# Altium schematic sheet rtc.SchDoc
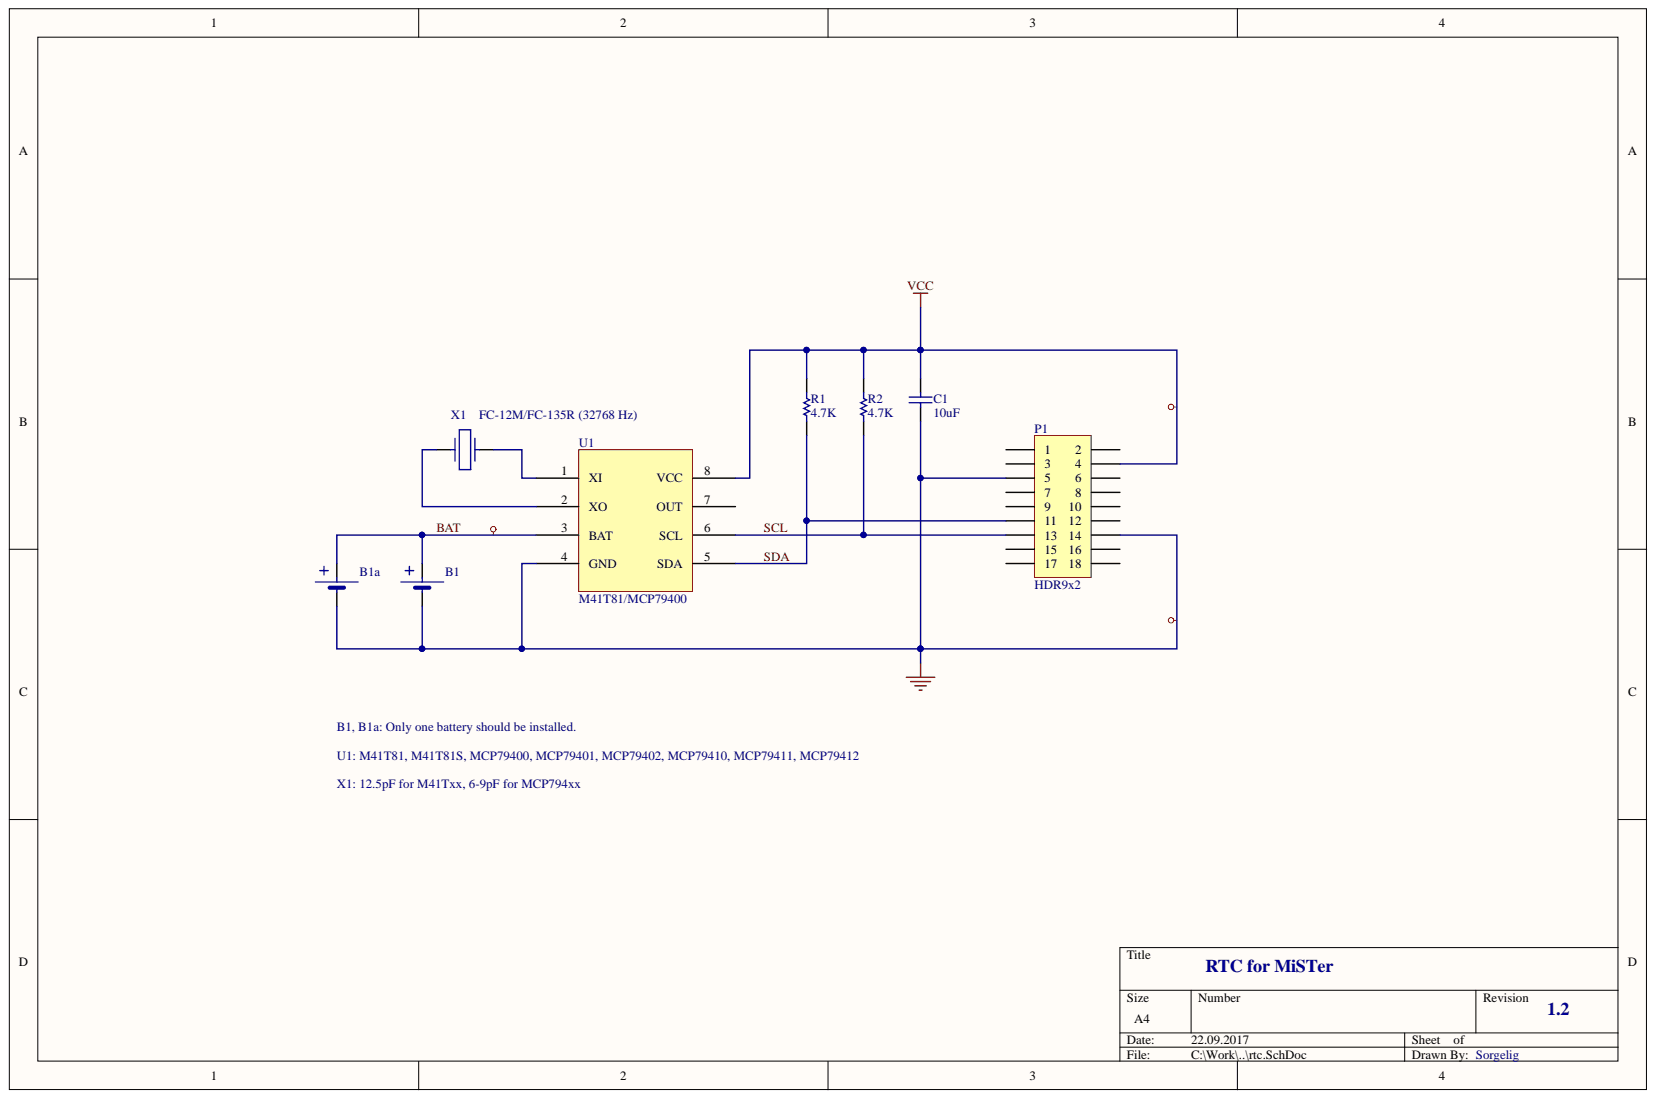
[diagram p0: rtc.SchDoc]

## Parts
B1  (no value)  [KS3000]
B1a  BAT  [BAT]  (value not drawn)
C1  value 10uF  [C0805]  (hidden comment: C0805)
P1  HDR9x2  [hdr9x2]
R1  4.7K  [R0805]
R2  4.7K  [R0805]
U1  M41T81/MCP79400  [SOIC8P]
X1  FC-12M/FC-135R (32768 Hz)  [OSC]

## Nets
GND: B1.1 B1a.1 C1.1 P1.5 P1.14 U1.4(GND)
VCC: C1.2 P1.4 R1.2 R2.2 U1.8(VCC)
BAT: B1.2 B1a.2 U1.3(BAT)
SCL: P1.13 R2.1 U1.6(SCL)
SDA: P1.11 R1.1 U1.5(SDA)
NetU1_1: U1.1(XI) X1.1
NetU1_2: U1.2(XO) X1.2

Not connected: P1 1 2 3 6 7 8 9 10 12 15 16 17 18; U1 7(OUT)

## Library data of the parts
B1 = BAT_KS3000: Component Kind: Standard; Library Name: lib.SchLib
C1 = C0805: Ceramic Chip Capacitor - Standard; Note: Footprint corresponds to dimensions for bulk cassette packaging. This case size has tightened tolerances.; Code_IPC: 2012[0805]; Code_JEITA: 0805; Component Kind: Standard; ComponentLink1Description: Manufacturer Link; ComponentLink1URL: http://www.kemet.com/; ComponentLink2Description: Datasheet; ComponentLink2URL: http://www.kemet.com/kemet/web/homepage/kechome.nsf/vapubfilesname/F3102TA.pdf/$file/F3102TA.pdf; DatasheetDocument: Mar-2001; LatestRevisionDate: 19-Mar-2004; LatestRevisionNote: Silkscreen size reduced.; Library Name: KEMET Chip Capacitor.IntLib; PackageDocument: Mar-2001; PackageReference: 0805; Published: 17-Jul-2002; Signal Integrity: C0805; Simulation: CAP; Publisher: Altium Limited
R1 R2 = ERJ6RS: Low Ohmic Value Thick Film Chip Resistor, 0.1 Ohm to 0.2 Ohm Range, 1%, 2% and 5% Tolerance, 0805 Size, 0.125 W; Code_IPC: 2012 [0805]; Component Kind: Standard; ComponentLink1Description: Manufacturer Link; ComponentLink1URL: http://www.panasonic.com/industrial/components/resistive_products.htm; ComponentLink2Description: Datasheet; ComponentLink2URL: http://www.panasonic.com/industrial/components/pdf/AOA0000CE3.pdf; LatestRevisionDate: 19-Mar-2004; LatestRevisionNote: Silkscreen size reduced.; Library Name: lib.PcbLib; PackageDocument: Mar-2003; PackageReference: 6-0805; Published: 17-Dec-2003; Signal Integrity: Res; Simulation: RESISTOR; Publisher: Altium Limited
U1 = M41T: Component Kind: Standard; Library Name: lib.SchLib
X1 = OSC: Component Kind: Standard; Library Name: lib.SchLib
B1a = BAT: Component Kind: Standard; Library Name: lib.SchLib
P1 = HDR9x2: Component Kind: Standard; Library Name: lib.SchLib
